# Altium schematic sheet MainMCU.SchDoc(MainMCU)
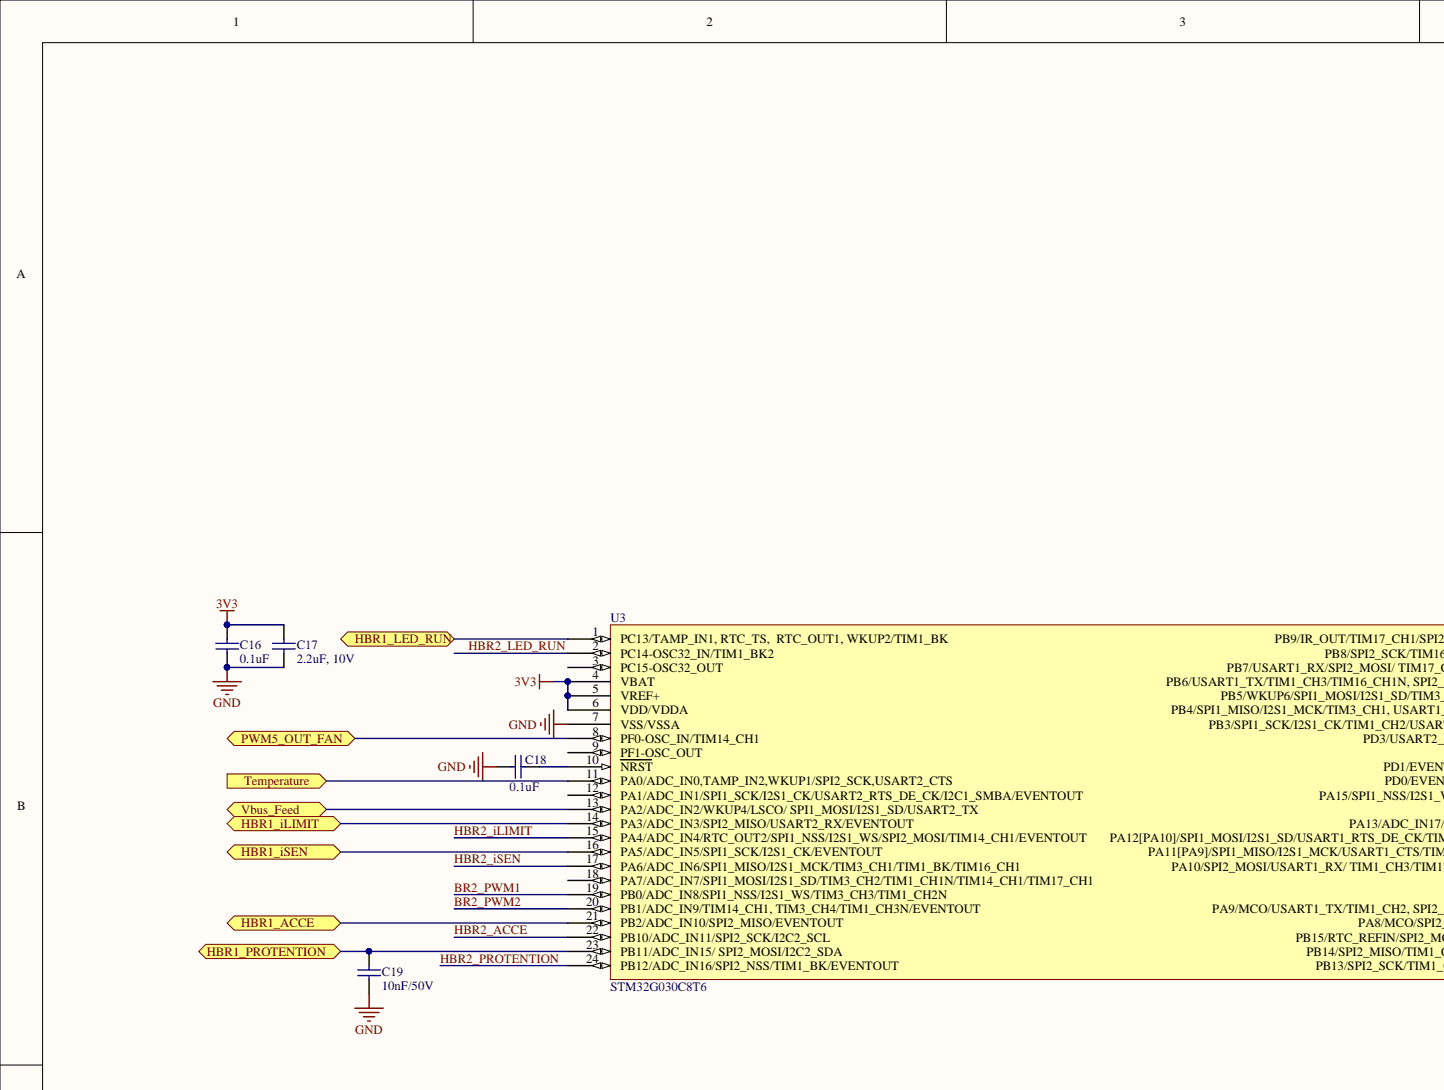
[diagram p0: MainMCU.SchDoc, top left]
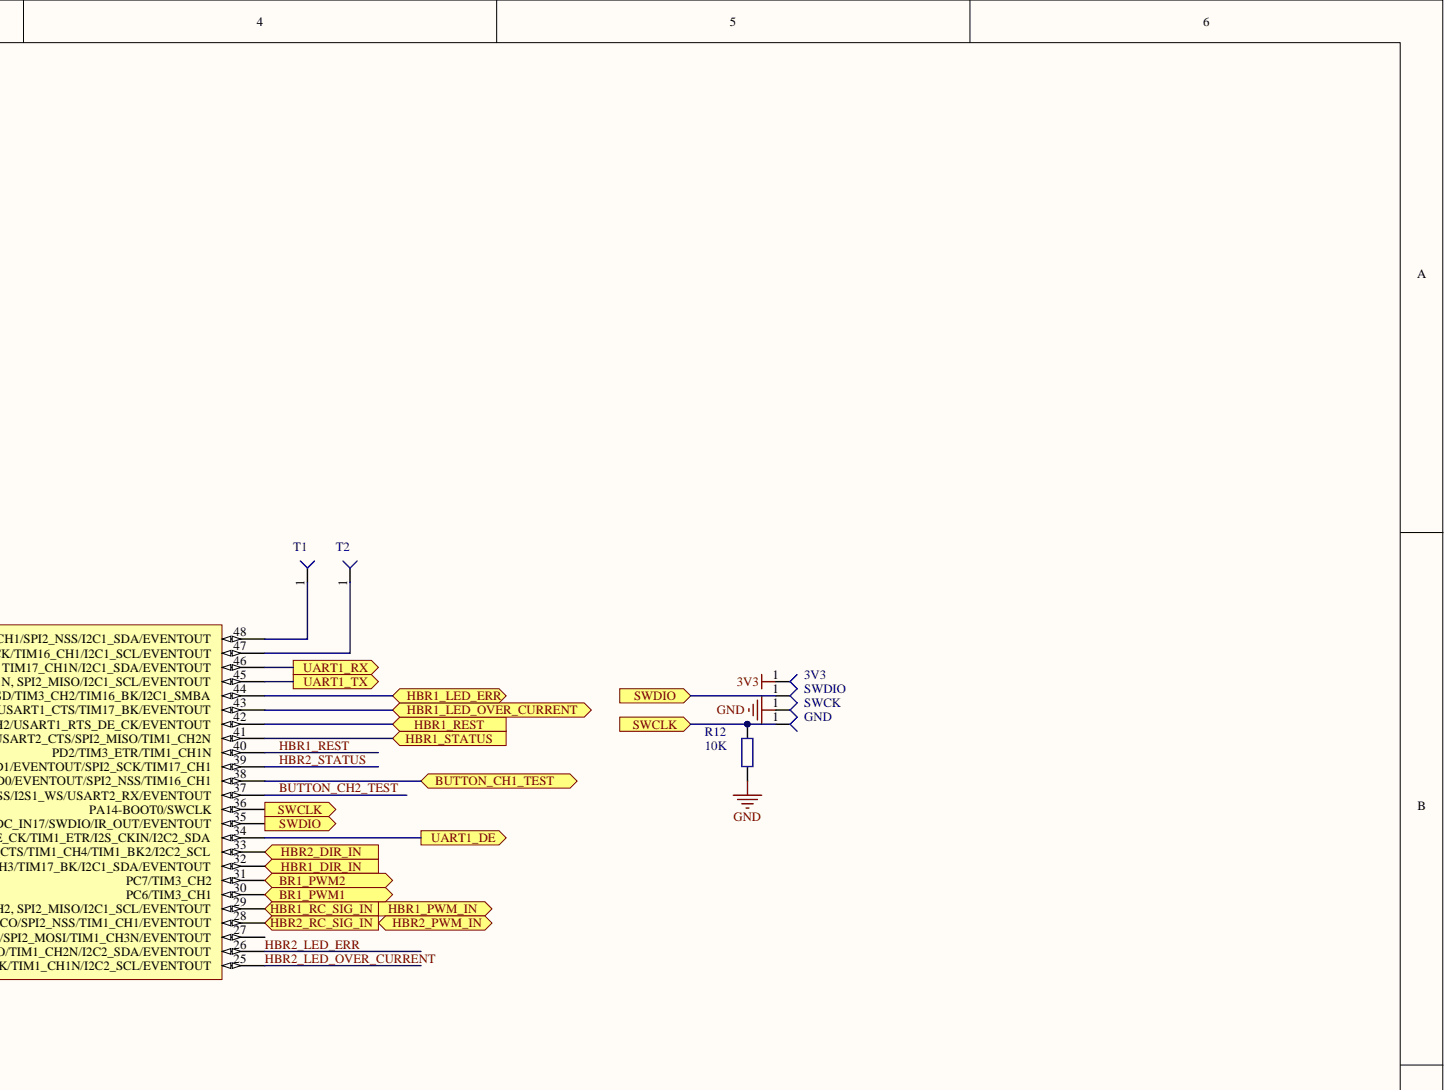
[diagram p1: MainMCU.SchDoc, top right]
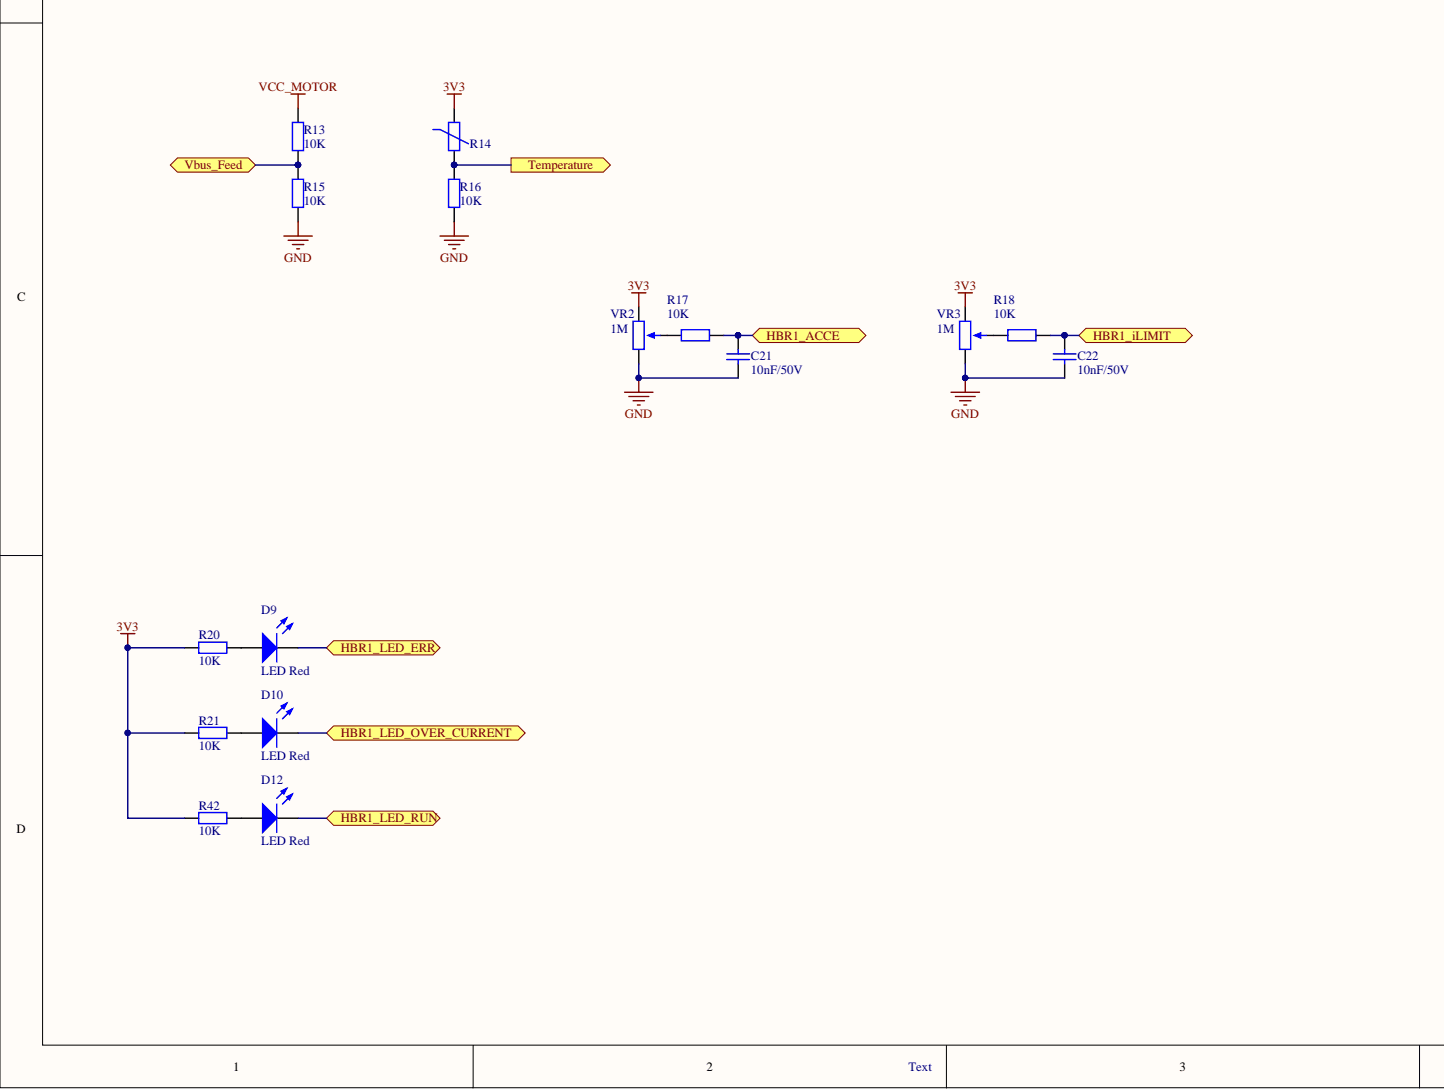
[diagram p2: MainMCU.SchDoc, bottom left]
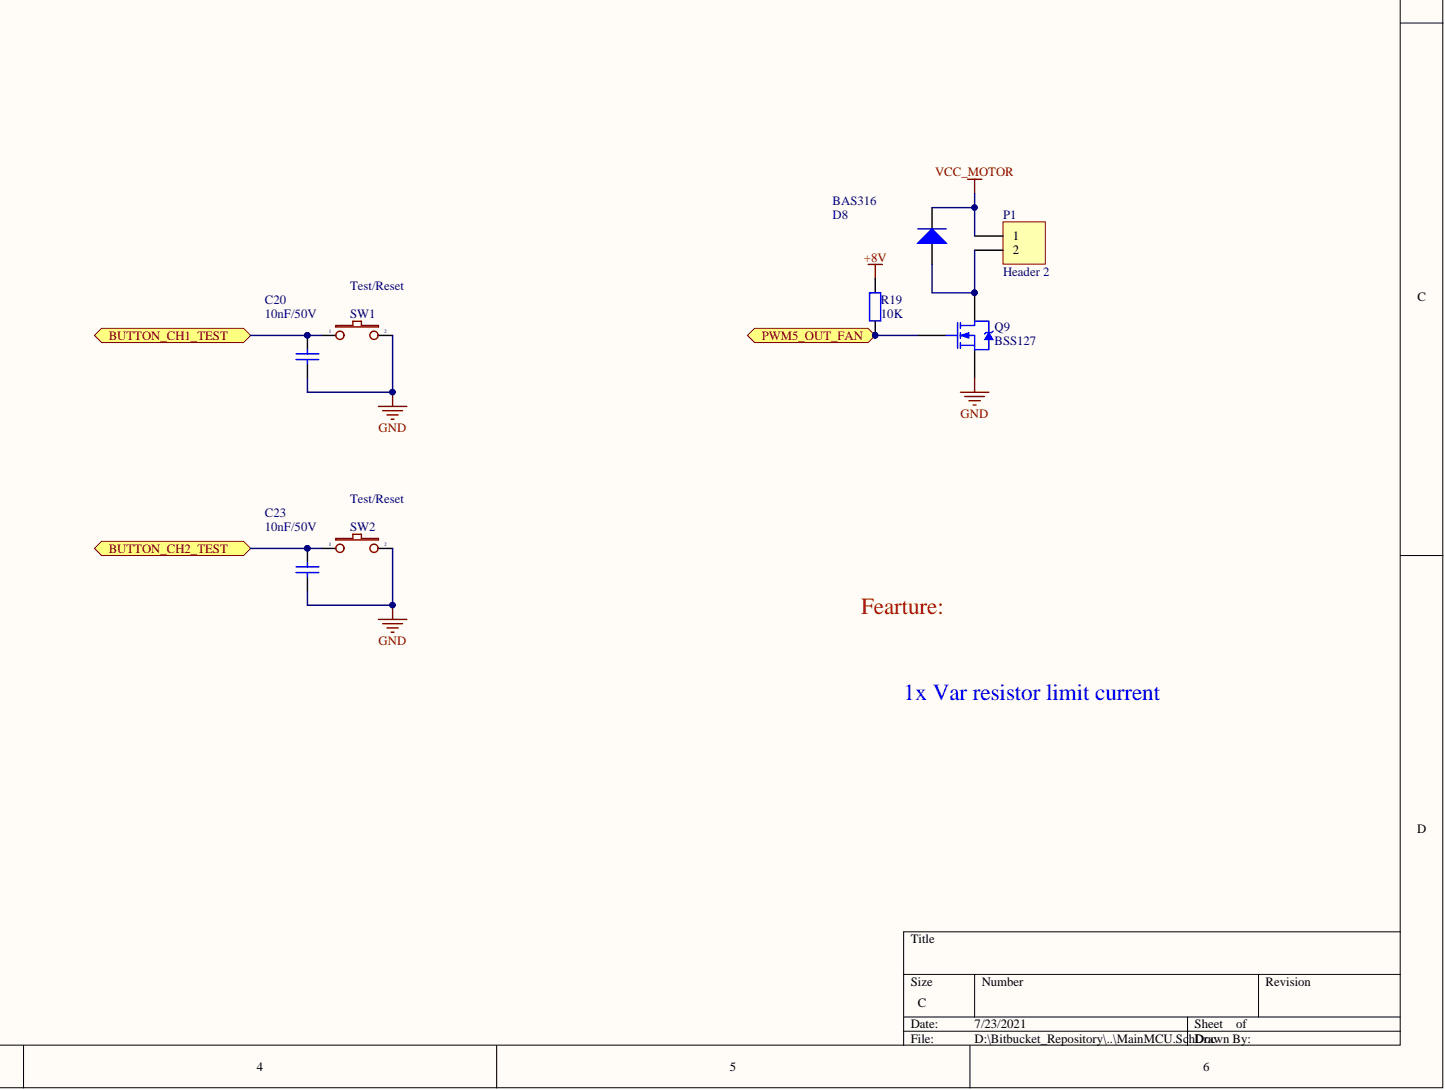
[diagram p3: MainMCU.SchDoc, bottom right]

## Parts
3V3  Test point  [Test point 1- 1.5 mm]  (value not drawn)
C16  value 0.1uF  [C0603]  (hidden comment: Ceramic Cap 0603 0.1uF 50V 10% X7R)
C17  value 2.2uF, 10V  [C0603]  (hidden comment: Ceramic Cap 0603 2.2uF 10V 10% X7R)
C18  value 0.1uF  [C0603]  (hidden comment: Ceramic Cap 0603 0.1uF 50V 10% X7R)
C19  value 10nF/50V  [C0603]  (hidden comment: Ceramic Cap 0603 0.01uF 50V 10% X7R)
C20  value 10nF/50V  [C0603]  (hidden comment: Ceramic Cap 0603 0.01uF 50V 10% X7R)
C21  value 10nF/50V  [C0603]  (hidden comment: Ceramic Cap 0603 0.01uF 50V 10% X7R)
C22  value 10nF/50V  [C0603]  (hidden comment: Ceramic Cap 0603 0.01uF 50V 10% X7R)
C23  value 10nF/50V  [C0603]  (hidden comment: Ceramic Cap 0603 0.01uF 50V 10% X7R)
D8  BAS316  [SOD-323]
D9  LED Red  [LED0603-R]
D10  LED Red  [LED0603-R]
D12  LED Red  [LED0603-R]
GND  Test point  [Test point 1- 1.5 mm]  (value not drawn)
P1  Header 2  [HDR1X2]
Q9  value BSS127  [SOT-23]  (hidden comment: MOSFET N-CH 600V 0.05A SOT23)
R12  value 10K  [R0603]  (hidden comment: RES 10K 1% 1/10W 0603)
R13  value 10K  [R0603]  (hidden comment: RES 10K 1% 1/10W 0603)
R14  NTC  [R0603]  (value not drawn)
R15  value 10K  [R0603]  (hidden comment: RES 10K 1% 1/10W 0603)
R16  value 10K  [R0603]  (hidden comment: RES 10K 1% 1/10W 0603)
R17  value 10K  [R0603]  (hidden comment: RES 10K 1% 1/10W 0603)
R18  value 10K  [R0603]  (hidden comment: RES 10K 1% 1/10W 0603)
R19  value 10K  [R0603]  (hidden comment: RES 10K 1% 1/10W 0603)
R20  value 10K  [R0603]  (hidden comment: RES 10K 1% 1/10W 0603)
R21  value 10K  [R0603]  (hidden comment: RES 10K 1% 1/10W 0603)
R42  value 10K  [R0603]  (hidden comment: RES 10K 1% 1/10W 0603)
SW1  Test/Reset  [PTS810]
SW2  Test/Reset  [PTS810]
SWCK  Test point  [Test point 1- 1.5 mm]  (value not drawn)
SWDIO  Test point  [Test point 1- 1.5 mm]  (value not drawn)
T1  Test point  [Test point 1- 1.5 mm]  (value not drawn)
T2  Test point  [Test point 1- 1.5 mm]  (value not drawn)
U3  STM32G030C8T6  [TQFP-48-P0.5]
VR2  value 1M  [POT 44W]  (hidden comment: TRIMMER 1M SMD)
VR3  value 1M  [POT 44W]  (hidden comment: TRIMMER 1M SMD)

## Ports
BR1_PWM1, BR1_PWM2, BUTTON_CH1_TEST, BUTTON_CH2_TEST, HBR1_ACCE, HBR1_DIR_IN, HBR1_ILIMIT, HBR1_ISEN, HBR1_LED_ERR, HBR1_LED_OVER_CURRENT, HBR1_LED_RUN, HBR1_PROTENTION, HBR1_PWM_IN, HBR1_RC_SIG_IN, HBR1_REST, HBR1_STATUS, HBR2_DIR_IN, HBR2_PWM_IN, HBR2_RC_SIG_IN, PWM5_OUT_FAN, SWCLK, SWDIO, TEMPERATURE, UART1_DE, UART1_RX, UART1_TX, VBUS_FEED

## Nets
3V3: 3V3.1 C16.2 C17.2 R14.2 R20.2 R21.2 R42.2 U3.4(VBAT) U3.5(VREF+) U3.6(VDD/VDDA) VR2.1 VR3.1
+8V: R19.2
GND: C16.1 C17.1 C18.2 C19.1 C20.1 C21.1 C22.1 C23.1 Q9.2 R12.1 R15.1 R16.1 SW1.2 SW2.2 SWCK.1 U3.7(VSS/VSSA) VR2.2 VR3.2
VCC_MOTOR: D8.2 P1.1 R13.2
BR1_PWM1: U3.30(PC6/TIM3_CH1) ; port BR1_PWM1
BR1_PWM2: U3.31(PC7/TIM3_CH2) ; port BR1_PWM2
BR2_PWM1: U3.19(PB0/ADC_IN8/SPI1_NSS/I2S1_WS/TIM3_CH3/TIM1_CH2N)
BR2_PWM2: U3.20(PB1/ADC_IN9/TIM14_CH1,_TIM3_CH4/TIM1_CH3N/EVENTOUT)
BUTTON_CH1_TEST: C20.2 SW1.1 U3.38(PD0/EVENTOUT/SPI2_NSS/TIM16_CH1) ; port BUTTON_CH1_TEST
BUTTON_CH2_TEST: U3.37(PA15/SPI1_NSS/I2S1_WS/USART2_RX/EVENTOUT)
HBR1_ACCE: C21.2 R17.1 U3.21(PB2/ADC_IN10/SPI2_MISO/EVENTOUT) ; port HBR1_ACCE
HBR1_DIR_IN: U3.32(PA10/SPI2_MOSI/USART1_RX/_TIM1_CH3/TIM17_BK/I2C1_SDA/EVENTOUT) ; port HBR1_DIR_IN
HBR1_LED_ERR: D9.2 U3.44(PB5/WKUP6/SPI1_MOSI/I2S1_SD/TIM3_CH2/TIM16_BK/I2C1_SMBA) ; port HBR1_LED_ERR
HBR1_LED_OVER_CURRENT: D10.2 U3.43(PB4/SPI1_MISO/I2S1_MCK/TIM3_CH1,_USART1_CTS/TIM17_BK/EVENTOUT) ; port HBR1_LED_OVER_CURRENT
HBR1_LED_RUN: D12.2 U3.1(PC13/TAMP_IN1,_RTC_TS,) ; port HBR1_LED_RUN
HBR1_PROTENTION: C19.2 U3.23(PB11/ADC_IN15/_SPI2_MOSI/I2C2_SDA) ; port HBR1_PROTENTION
HBR1_PWM_IN: U3.29(PA9/MCO/USART1_TX/TIM1_CH2,_SPI2_MISO/I2C1_SCL/EVENTOUT) ; port HBR1_PWM_IN, port HBR1_RC_SIG_IN
HBR1_REST: U3.40(PD2/TIM3_ETR/TIM1_CH1N)
HBR1_STATUS: U3.41(PD3/USART2_CTS/SPI2_MISO/TIM1_CH2N) ; port HBR1_STATUS
HBR2_ACCE: U3.22(PB10/ADC_IN11/SPI2_SCK/I2C2_SCL)
HBR2_DIR_IN: U3.33(PA11[PA9]/SPI1_MISO/I2S1_MCK/USART1_CTS/TIM1_CH4/TIM1_BK2/I2C2_SCL) ; port HBR2_DIR_IN
HBR2_ILIMIT: U3.15(PA4/ADC_IN4/RTC_OUT2/SPI1_NSS/I2S1_WS/SPI2_MOSI/TIM14_CH1/EVENTOUT)
HBR2_ISEN: U3.17(PA6/ADC_IN6/SPI1_MISO/I2S1_MCK/TIM3_CH1/TIM1_BK/TIM16_CH1)
HBR2_LED_ERR: U3.26(PB14/SPI2_MISO/TIM1_CH2N/I2C2_SDA/EVENTOUT)
HBR2_LED_OVER_CURRENT: U3.25(PB13/SPI2_SCK/TIM1_CH1N/I2C2_SCL/EVENTOUT)
HBR2_LED_RUN: U3.2(PC14-OSC32_IN/TIM1_BK2)
HBR2_PROTENTION: U3.24(PB12/ADC_IN16/SPI2_NSS/TIM1_BK/EVENTOUT)
HBR2_PWM_IN: U3.28(PA8/MCO/SPI2_NSS/TIM1_CH1/EVENTOUT) ; port HBR2_PWM_IN, port HBR2_RC_SIG_IN
HBR2_STATUS: U3.39(PD1/EVENTOUT/SPI2_SCK/TIM17_CH1)
PWM5_OUT_FAN: Q9.1 R19.1 U3.8(PF0-OSC_IN/TIM14_CH1) ; port PWM5_OUT_FAN
SWCLK: GND.1 R12.2 U3.36(PA14-BOOT0/SWCLK) ; port SWCLK
SWDIO: SWDIO.1 U3.35(PA13/ADC_IN17/SWDIO/IR_OUT/EVENTOUT) ; port SWDIO
UART1_DE: U3.34(PA12[PA10]/SPI1_MOSI/I2S1_SD/USART1_RTS_DE_CK/TIM1_ETR/I2S_CKIN/I2C2_SDA) ; port UART1_DE
UART1_RX: U3.46(PB7/USART1_RX/SPI2_MOSI/_TIM17_CH1N/I2C1_SDA/EVENTOUT) ; port UART1_RX
UART1_TX: U3.45(PB6/USART1_TX/TIM1_CH3/TIM16_CH1N,_SPI2_MISO/I2C1_SCL/EVENTOUT) ; port UART1_TX
NetC18_1: C18.1 U3.10(NRST)
NetC22_2: C22.2 R18.1 U3.14(PA3/ADC_IN3/SPI2_MISO/USART2_RX/EVENTOUT) ; port HBR1_ILIMIT
NetC23_2: C23.2 SW2.1 ; port BUTTON_CH2_TEST
NetD8_1: D8.1 P1.2 Q9.3
NetD9_1: D9.1 R20.1
NetD10_1: D10.1 R21.1
NetD12_1: D12.1 R42.1
NetR13_1: R13.1 R15.2 U3.13(PA2/ADC_IN2/WKUP4/LSCO/_SPI1_MOSI/I2S1_SD/USART2_TX) ; port VBUS_FEED
NetR14_1: R14.1 R16.2 U3.11(PA0/ADC_IN0,TAMP_IN2,WKUP1/SPI2_SCK,USART2_CTS) ; port TEMPERATURE
NetR17_2: R17.2 VR2.3
NetR18_2: R18.2 VR3.3
NetT1_1: T1.1 U3.48(PB9/IR_OUT/TIM17_CH1/SPI2_NSS/I2C1_SDA/EVENTOUT)
NetT2_1: T2.1 U3.47(PB8/SPI2_SCK/TIM16_CH1/I2C1_SCL/EVENTOUT)
NetU3_16: U3.16(PA5/ADC_IN5/SPI1_SCK/I2S1_CK/EVENTOUT) ; port HBR1_ISEN
NetU3_42: U3.42(PB3/SPI1_SCK/I2S1_CK/TIM1_CH2/USART1_RTS_DE_CK/EVENTOUT) ; port HBR1_REST

Not connected: U3 3(PC15-OSC32_OUT) 9(PF1-OSC_OUT) 12(PA1/ADC_IN1/SPI1_SCK/I2S1_CK/USART2_RTS_DE_CK/I2C1_SMBA/EVENTOUT) 18(PA7/ADC_IN7/SPI1_MOSI/I2S1_SD/TIM3_CH2/TIM1_CH1N/TIM14_CH1/TIM17_CH1) 27(PB15/RTC_REFIN/SPI2_MOSI/TIM1_CH3N/EVENTOUT)

## Library data of the parts
3V3 GND SWCK SWDIO T1 T2 = TEST_POINT: Component Kind: Standard; Library Name: lib.SchLib
C16 C18 = GRM188R71H104KA93: Murata General Purpose Ceramic Cap 0603 0.1uF 50V 10% X7R; Component Kind: Standard; Library Name: capacitor.SchLib; Signal Integrity: Cap; Simulation: CAP; Supplier 1: Digi-Key; Supplier Part Number 1: 490-1519-1-ND; Supplier Part Number 2: FL1028
C17 = GRM188R71A225KE15: Murata General Purpose Ceramic Cap 0603 2.2uF 10V 10% X7R; Component Kind: Standard; Library Name: capacitor.SchLib; Signal Integrity: Cap; Simulation: CAP; Supplier 1: Digi-Key; Supplier Part Number 1: 490-4520-1-ND
C19-C23 = GRM188R71H103KA01: Murata General Purpose Ceramic Cap 0603 0.01uF 50V 10% X7R; Component Kind: Standard; Library Name: capacitor.SchLib; Signal Integrity: Cap; Simulation: CAP; Supplier 1: Digi-Key; Supplier Part Number 1: 490-1512-1-ND; Supplier Part Number 2: FL1129
D8 = BAS316: Fast Switching Diode 100V 0.25A SOD-323; Component Kind: Standard; Library Name: diode.SchLib; Supplier 1: Digi-Key; Supplier Part Number 1: 568-5003-1-ND; Supplier Part Number 2: FL1504; Value: BAS316
D9 D10 D12 = LTST-C190KRKT: Lite-On LED Red 0603; Component Kind: Standard; Library Name: diode.SchLib; Supplier 1: Digi-Key; Supplier Part Number 1: 160-1436-1-ND; Supplier Part Number 2: FL1413; Value: LED Red
P1 = Header 2: Header, 2-Pin; Component Kind: Standard; LatestRevisionDate: 17-Jul-2002; LatestRevisionNote: Re-released for DXP Platform.; Library Name: Miscellaneous Connectors.IntLib; Signal Integrity: Connector; Publisher: Altium Limited
Q9 = BSS127S-7DICT-ND: N-Channel 600V 50mA (Ta) 610mW (Ta) Surface Mount SOT-23; Component Kind: Standard; Library Name: fet.SchLib; Supplier 1: Digi-Key; Supplier Part Number 1: BSS127S-7DICT-ND; Supplier Part Number 2: FL2919
R12 R13 R15-R21 R42 = RC0603FR-0710KL: Yageo RES 10K 1% 1/10W 0603; Component Kind: Standard; Library Name: resistor.SchLib; Signal Integrity: Res; Simulation: RESISTOR; Supplier 1: Digi-Key; Supplier Part Number 1: 311-10.0KHRCT-ND
R14 = NTCG164QH105HT1: NTC THERMISTOR 1M OHM 3% 0603; Component Kind: Standard; Library Name: sensor.SchLib; Supplier 1: Digi-Key; Supplier Part Number 1: 445-174918-2-ND; Supplier Part Number 2: FL2556
SW1 SW2 = SW2: Switch button 2 pin, SMD; Value: SW_2; Component Kind: Standard; Library Name: button.SchLib
U3 = STM32G030C8T6: 48pins, 64 KBytes Flash, 8 KBytes SRAM, 1x I2C, 1x SPI (18 Mbit/s); Component Kind: Standard; Library Name: mcu.SchLib; Value: STM32G030C8T6
VR2 VR3 = 44WR1MEGLF: BI Technologies Trimmer 1M SMD; Component Kind: Standard; Library Name: resistor.SchLib; Signal Integrity: Res; Simulation: RESISTOR; Supplier 1: Farnell; Supplier Part Number 1: 1520654
